# Altium schematic sheet 7 Display_V0.SchDoc
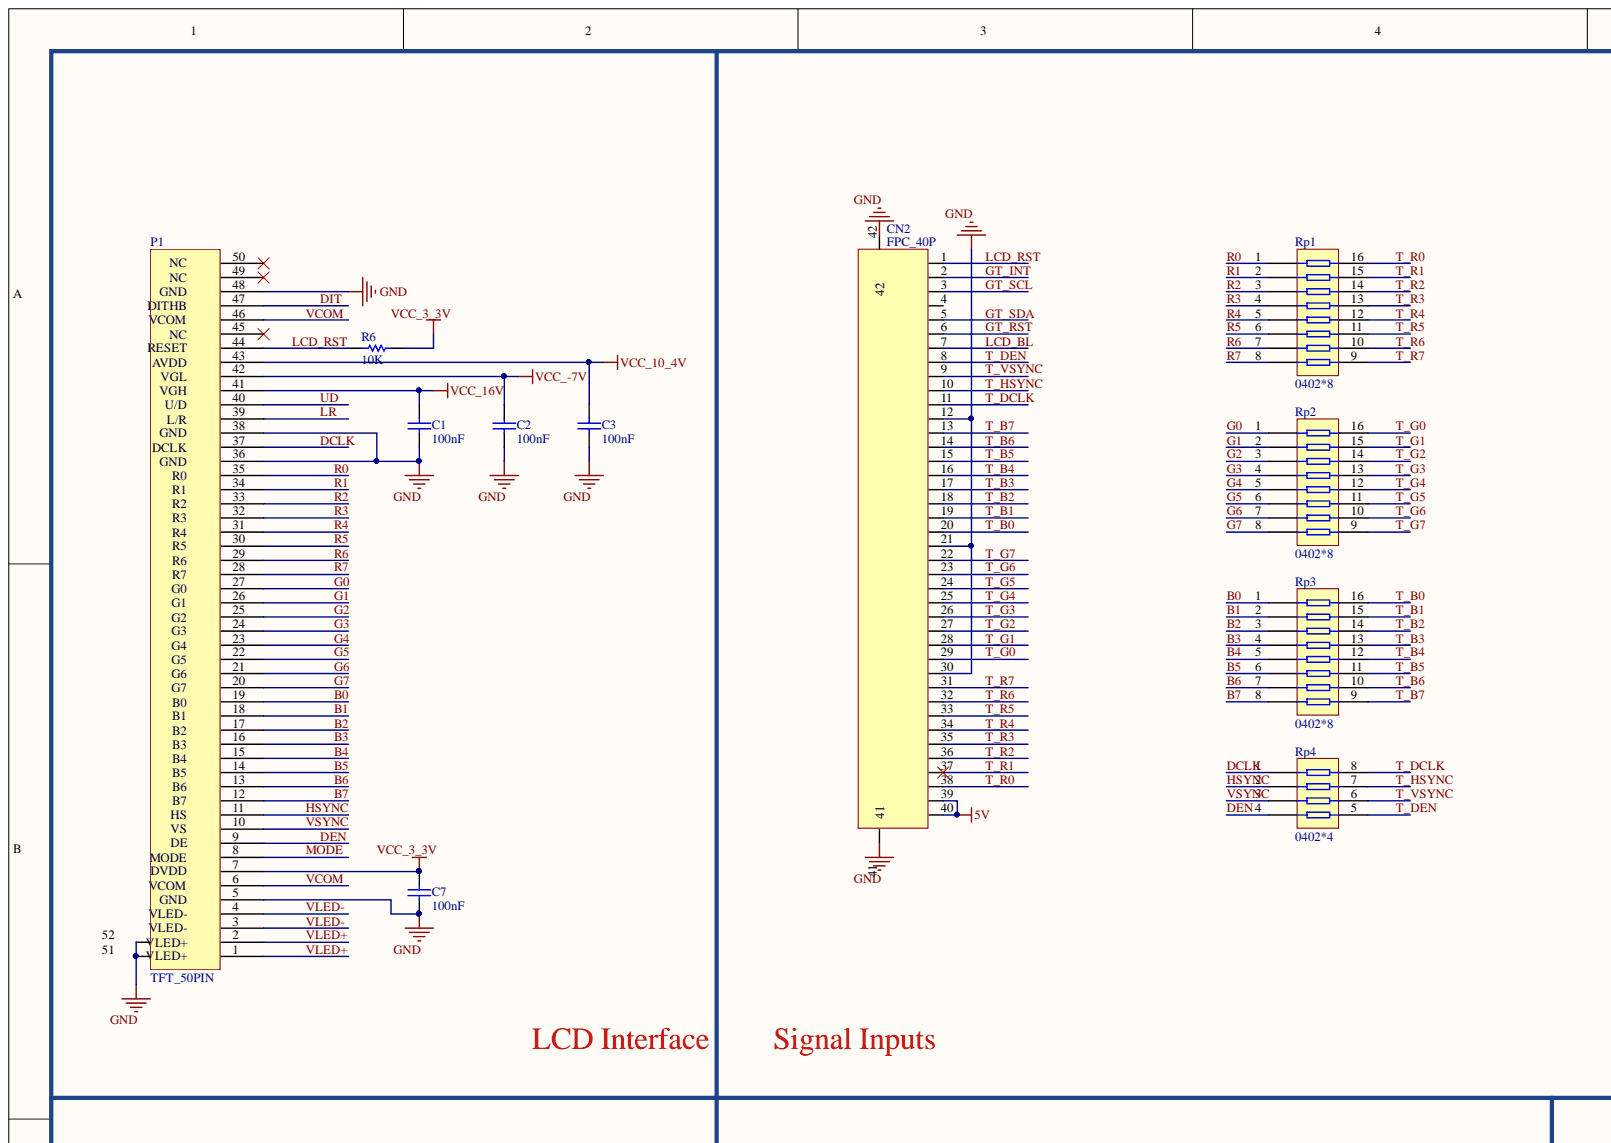
[diagram p0: 7 Display_V0.SchDoc, top left]
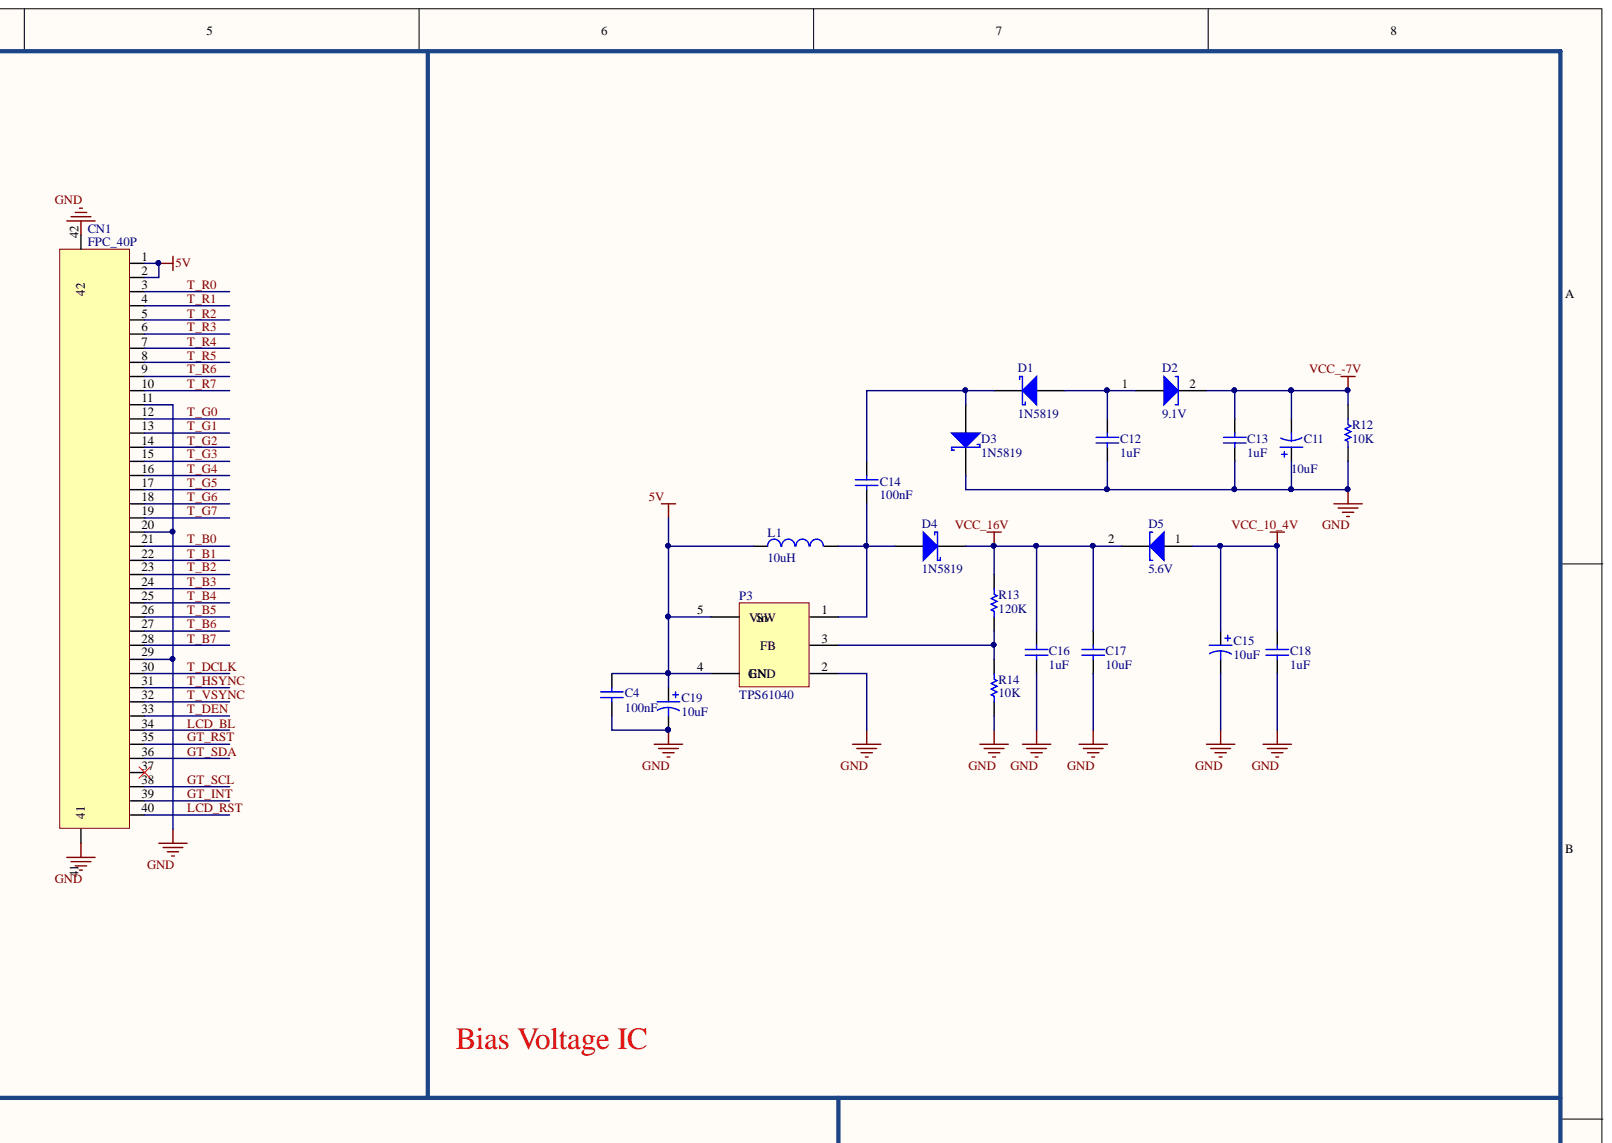
[diagram p1: 7 Display_V0.SchDoc, top right]
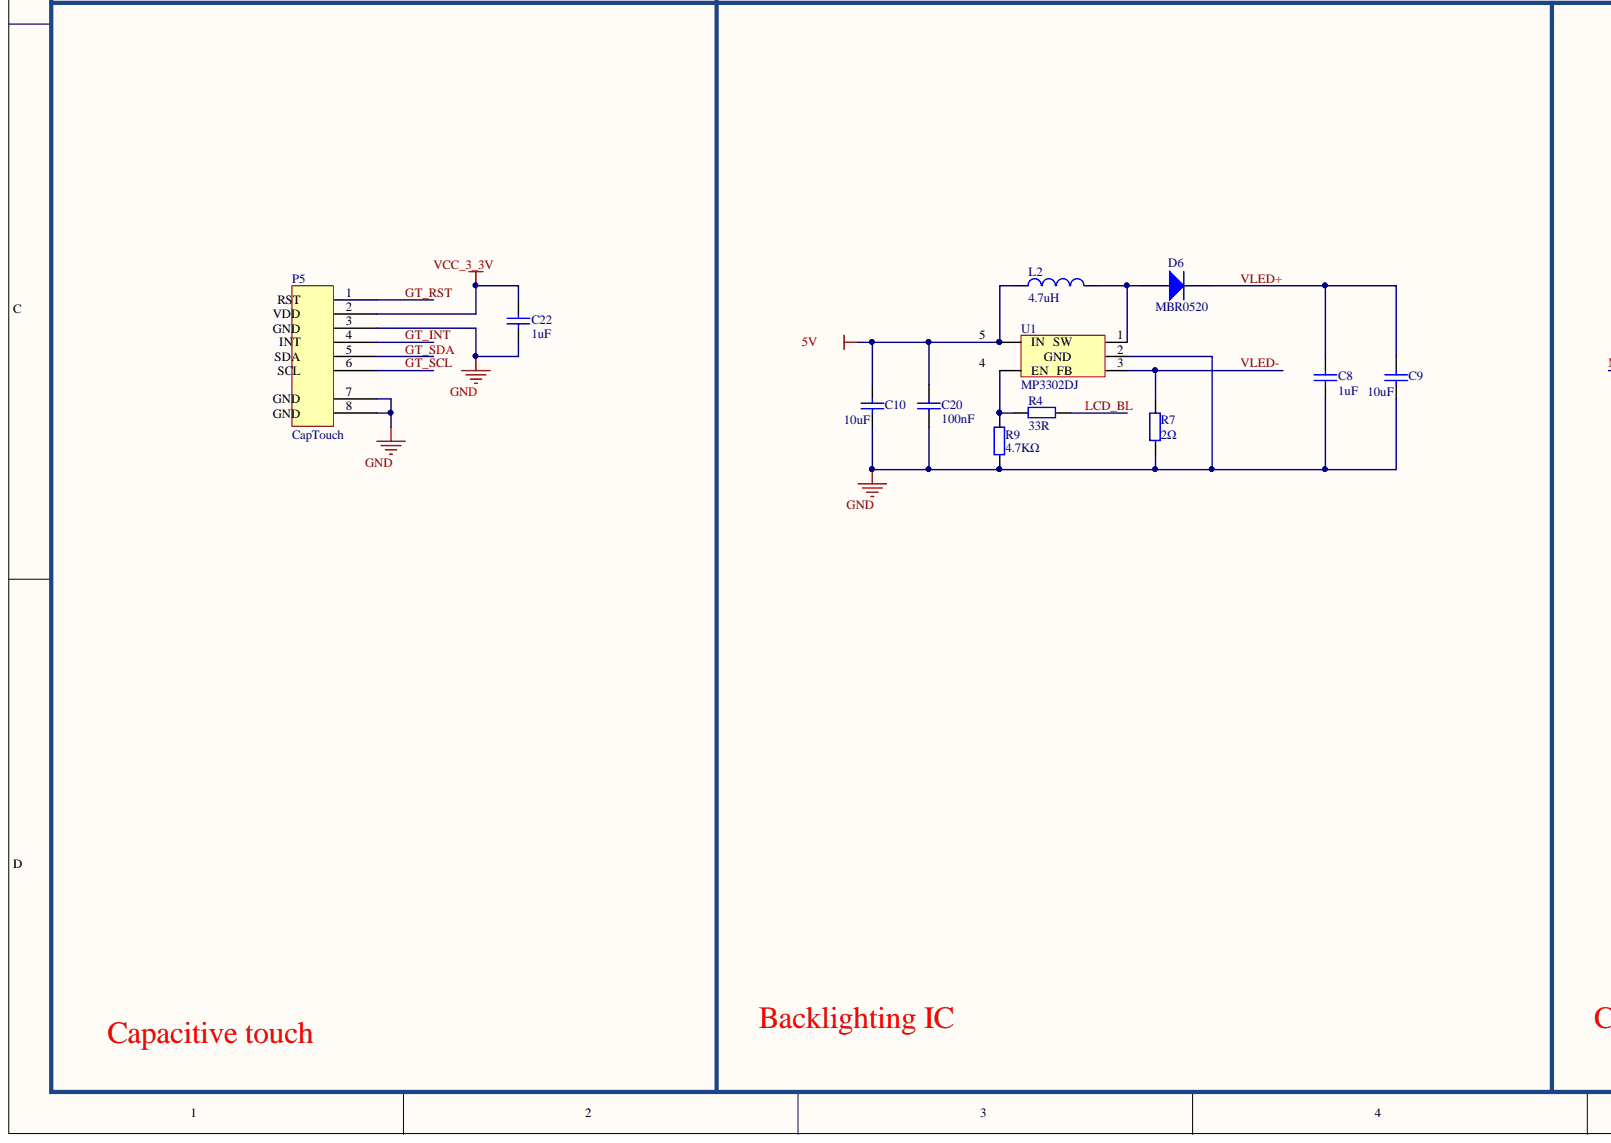
[diagram p2: 7 Display_V0.SchDoc, bottom left]
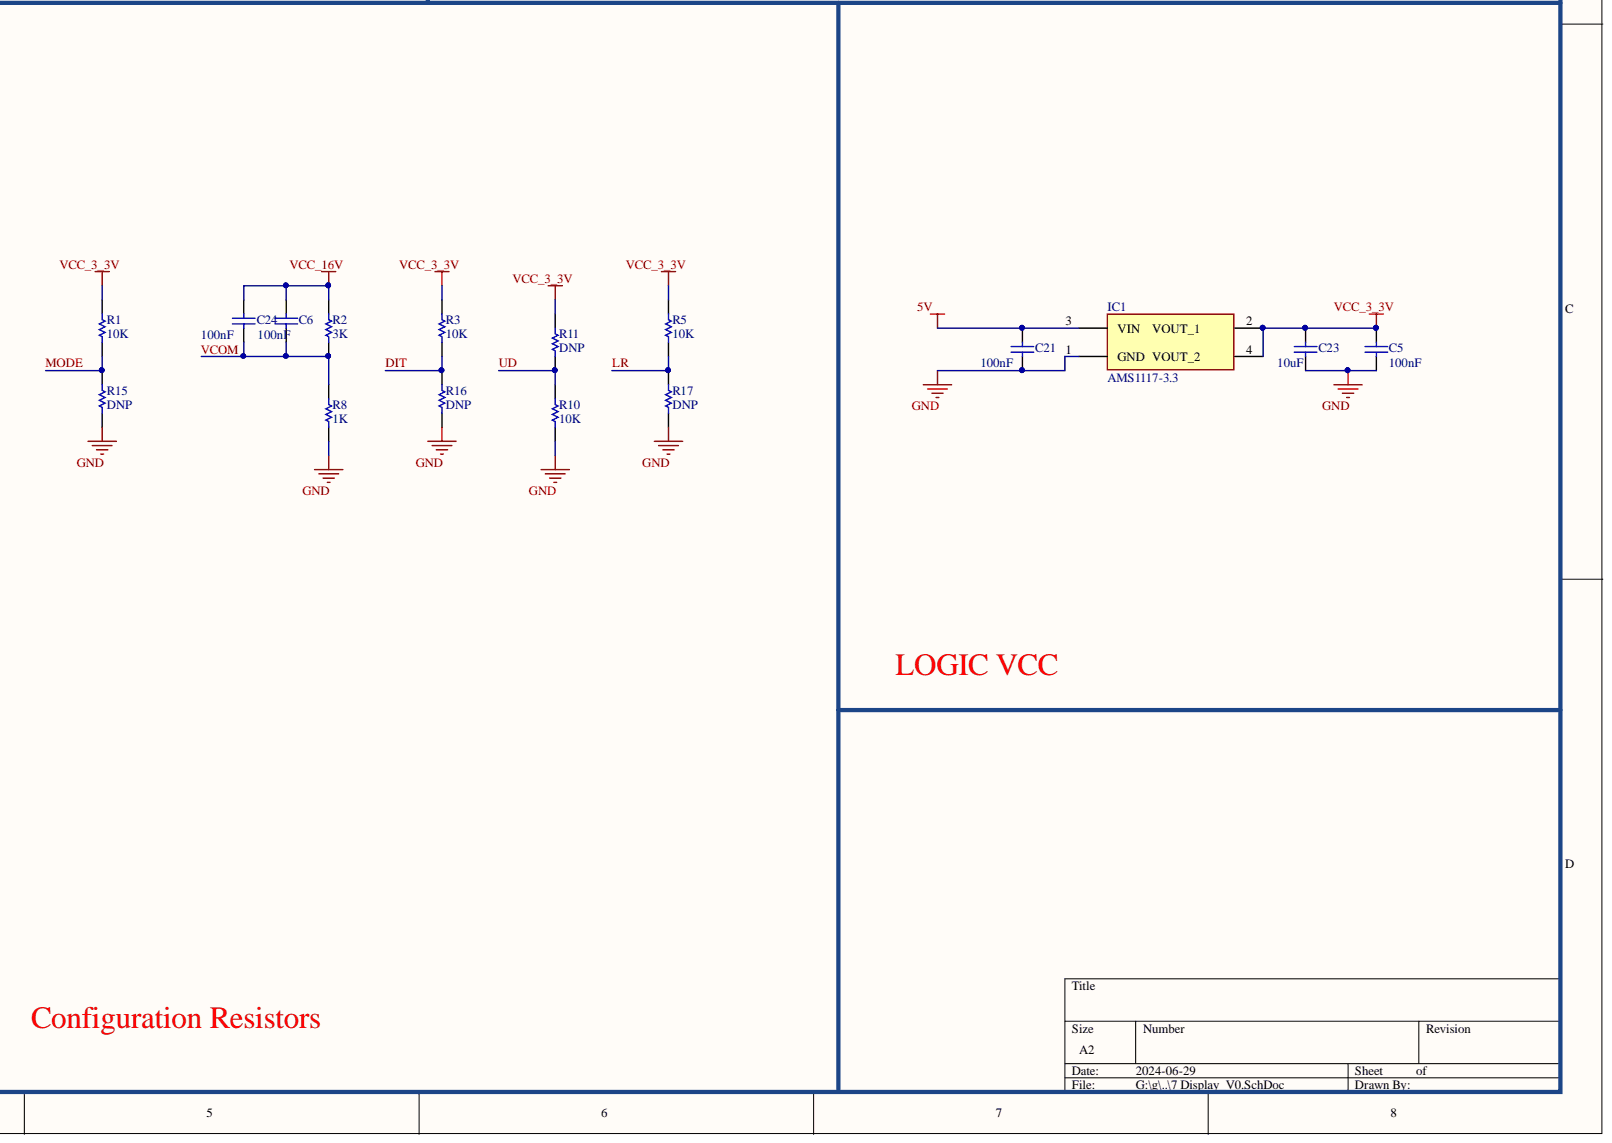
[diagram p3: 7 Display_V0.SchDoc, bottom right]

## Parts
C1  100nF  [C0603]
C2  100nF  [C0603]
C3  100nF  [C0603]
C4  100nF  [C0603]
C5  100nF  [C0603]
C6  100nF  [C0603]
C7  100nF  [C0603]
C8  1uF  [0603_C]
C9  10uF  [1206_C]
C10  10uF  [1206_C]
C11  10uF  [1206_C]
C12  1uF  [C0603]
C13  1uF  [C0603]
C14  100nF  [C0603]
C15  10uF  [1206_C]
C16  1uF  [C0603]
C17  10uF  [1206_C]
C18  1uF  [C0603]
C19  10uF  [1206_C]
C20  100nF  [0603_C]
C21  100nF  [0603_C]
C22  1uF  [C0603]
C23  10uF  [1206_C]
C24  100nF  [C0603]
CN1  FPC_40P  [FPC-SMD_P0.50-40P_FGS-XJ-H2.0]
CN2  FPC_40P  [FPC-SMD_P0.50-40P_FGS-XJ-H2.0]
D1  1N5819  [CAPMP4327X24N]
D2  9.1V  [CAPMP4327X24N]
D3  1N5819  [CAPMP4327X24N]
D4  1N5819  [CAPMP4327X24N]
D5  5.6V  [CAPMP4327X24N]
D6  MBR0520  [SOD-123]
IC1  AMS1117-3.3  [SOT229P700X180-4N]
L1  10uH  [L1008]
L2  4.7uH  [L1008]
P1  TFT_50PIN  [FPC50]
P3  TPS61040  [SOT95P280X110-5N]
P5  CapTouch  [FPC6]
R1  10K  [R0603]
R2  3K  [R0603]
R3  10K  [R0603]
R4  33R  [0603_R]
R5  10K  [R0603]
R6  10K  [R0603]
R7  2Ω  [0603_R]
R8  1K  [R0603]
R9  4.7KΩ  [0603_R]
R10  10K  [R0603]
R11  DNP  [R0603]
R12  10K  [R0603]
R13  120K  [R0603]
R14  10K  [R0603]
R15  DNP  [R0603]
R16  DNP  [R0603]
R17  DNP  [R0603]
Rp1  0402*8  [0402_Rx8]
Rp2  0402*8  [0402_Rx8]
Rp3  0402*8  [0402_Rx8]
Rp4  0402*4  [0402_Rx4]
U1  MP3302DJ  [SOT-23-5]

## Nets
5V: C4.2 C10.2 C19.1 C20.2 C21.2 CN1.1 CN1.2 CN2.39 CN2.40 IC1.3(VIN) L1.1 L2.1 P3.4(GND) P3.5(Vin) U1.5(IN)
GND: C1.1 C2.1 C3.1 C4.1 C5.1 C7.1 C8.2 C9.1 C10.1 C11.1 C12.1 C13.1 C15.2 C16.1 C17.1 C18.1 C19.2 C20.1 C21.1 C22.1 C23.1 CN1.11 CN1.20 CN1.29 CN1.41 CN1.42 CN2.12 CN2.21 CN2.30 CN2.41 CN2.42 D3.2 IC1.1(GND) P1.5 P1.36 P1.38 P1.48 P1.51(VLED+) P1.52(VLED+) P3.2 P5.3 P5.7 P5.8 R7.2 R8.1 R9.1 R10.1 R12.1 R14.1 R15.1 R16.1 R17.1 U1.2
VCC_3_3V: C5.2 C7.2 C22.2 C23.2 IC1.2 IC1.4 P1.7 P5.2 R1.2 R3.2 R5.2 R6.1 R11.2
VCC_10_4V: C3.2 C15.1 C18.2 D5.1 P1.43
VCC_16V: C1.2 C6.2 C16.2 C17.2 C24.2 D4.2 D5.2 P1.41 R2.2 R13.2
VCC_-7V: C2.2 C11.2 C13.2 D2.2 P1.42 R12.2
B0: P1.19 Rp3.1
B1: P1.18 Rp3.2
B2: P1.17 Rp3.3
B3: P1.16 Rp3.4
B4: P1.15 Rp3.5
B5: P1.14 Rp3.6
B6: P1.13 Rp3.7
B7: P1.12 Rp3.8
DCLK: P1.37 Rp4.1
DEN: P1.9 Rp4.4
DIT: P1.47 R3.1 R16.2
G0: P1.27 Rp2.1
G1: P1.26 Rp2.2
G2: P1.25 Rp2.3
G3: P1.24 Rp2.4
G4: P1.23 Rp2.5
G5: P1.22 Rp2.6
G6: P1.21 Rp2.7
G7: P1.20 Rp2.8
GT_INT: CN1.39 CN2.2 P5.4
GT_RST: CN1.35 CN2.6 P5.1
GT_SCL: CN1.38 CN2.3 P5.6
GT_SDA: CN1.36 CN2.5 P5.5
HSYNC: P1.11 Rp4.2
LCD_BL: CN1.34 CN2.7 R4.2
LCD_RST: CN1.40 CN2.1 P1.44 R6.2
LR: P1.39 R5.1 R17.2
MODE: P1.8 R1.1 R15.2
R0: P1.35 Rp1.1
R1: P1.34 Rp1.2
R2: P1.33 Rp1.3
R3: P1.32 Rp1.4
R4: P1.31 Rp1.5
R5: P1.30 Rp1.6
R6: P1.29 Rp1.7
R7: P1.28 Rp1.8
T_B0: CN1.21 CN2.20 Rp3.16
T_B1: CN1.22 CN2.19 Rp3.15
T_B2: CN1.23 CN2.18 Rp3.14
T_B3: CN1.24 CN2.17 Rp3.13
T_B4: CN1.25 CN2.16 Rp3.12
T_B5: CN1.26 CN2.15 Rp3.11
T_B6: CN1.27 CN2.14 Rp3.10
T_B7: CN1.28 CN2.13 Rp3.9
T_DCLK: CN1.30 CN2.11 Rp4.8
T_DEN: CN1.33 CN2.8 Rp4.5
T_G0: CN1.12 CN2.29 Rp2.16
T_G1: CN1.13 CN2.28 Rp2.15
T_G2: CN1.14 CN2.27 Rp2.14
T_G3: CN1.15 CN2.26 Rp2.13
T_G4: CN1.16 CN2.25 Rp2.12
T_G5: CN1.17 CN2.24 Rp2.11
T_G6: CN1.18 CN2.23 Rp2.10
T_G7: CN1.19 CN2.22 Rp2.9
T_HSYNC: CN1.31 CN2.10 Rp4.7
T_R0: CN1.3 CN2.38 Rp1.16
T_R1: CN1.4 CN2.37 Rp1.15
T_R2: CN1.5 CN2.36 Rp1.14
T_R3: CN1.6 CN2.35 Rp1.13
T_R4: CN1.7 CN2.34 Rp1.12
T_R5: CN1.8 CN2.33 Rp1.11
T_R6: CN1.9 CN2.32 Rp1.10
T_R7: CN1.10 CN2.31 Rp1.9
T_VSYNC: CN1.32 CN2.9 Rp4.6
UD: P1.40 R10.2 R11.1
VCOM: C6.1 C24.1 P1.6 P1.46 R2.1 R8.2
VLED+: C8.1 C9.2 D6.2 P1.1 P1.2
VLED-: P1.3 P1.4 R7.1 U1.3
VSYNC: P1.10 Rp4.3
NetC12_2: C12.2 D1.1 D2.1
NetC14_1: C14.1 D4.1 L1.2 P3.1
NetC14_2: C14.2 D1.2 D3.1
NetD6_1: D6.1 L2.2 U1.1
NetP3_3: P3.3 R13.1 R14.2
NetR4_1: R4.1 R9.2 U1.4(EN)

Not connected: CN1 37; CN2 4; P1 45 49 50

## Library data of the parts
C1-C7 C12-C14 C16-C18 C22 C24 = Cap: Capacitor; Component Kind: Standard; LatestRevisionDate: 17-Jul-2002; LatestRevisionNote: Re-released for DXP Platform.; Library Name: Miscellaneous Devices.IntLib; PackageDescription: Radial Cap, Thru-Hole; 2 Leads; 0.3 in Pin Spacing; PackageReference: RAD-0.3; Published: 8-Jun-2000; Signal Integrity: Cap; Simulation: CAP; Publisher: Altium Limited
  C1-C7 C14 C24: Value: 100nF
  C12 C13 C16 C18 C22: Value: 1uF
  C17: Value: 10uF
C8 = 0805 1uF (105) 10% 50V: 贴片电容; Component Kind: Standard; ComponentLink1Description: 供应商链接; ComponentLink1URL: http://www.szlcsc.com/product/details_29074.html; Library Name: Miscellaneous Devices LC.IntLib; Package: 0805; Supplier: LC; SuppliersPartNumber: C28323
C9 C10 C23 = 1206 1uF (105) 10% 50V: 贴片电容; Component Kind: Standard; ComponentLink1Description: 供应商链接; ComponentLink1URL: http://www.szlcsc.com/product/details_2200.html; Library Name: Miscellaneous Devices LC.IntLib; Package: 1206; Supplier: LC; SuppliersPartNumber: C1848
C11 C15 C19 = Cap Pol1: Polarized Capacitor (Radial); Component Kind: Standard; LatestRevisionDate: 17-Jul-2002; LatestRevisionNote: Re-released for DXP Platform.; Library Name: Miscellaneous Devices.IntLib; PackageDescription: Polarized Capacitor (Radial); 2 Leads; PackageReference: RB7.6-15; Published: 8-Jun-2000; Signal Integrity: Cap Pol; Simulation: CAP; Value: 10uF; Publisher: Altium Limited
C20 C21 = 0603 100nF (104) 10% 50V: 贴片电容; Component Kind: Standard; ComponentLink1Description: 供应商链接; ComponentLink1URL: http://www.szlcsc.com/product/details_15331.html; Library Name: Miscellaneous Devices LC.IntLib; Package: 0603; Supplier: LC; SuppliersPartNumber: C14663
D1 D3 D4 = Diode 11DQ03: SMA; Code_JEDEC: DO-204-AL; Component Kind: Standard; ComponentLink1Description: Manufacturer Link; ComponentLink1URL: http://www.irf.com/; ComponentLink2Description: Datasheet; ComponentLink2URL: http://www.irf.com/product-info/datasheets/data/11dq03.pdf; DatasheetVersion: Dec-1997; LatestRevisionDate: 17-Jul-2002; LatestRevisionNote: Re-released for DXP Platform.; Library Name: Miscellaneous Devices.IntLib; PackageDescription: Diode, Axial; Body 5.2 x 2.7 mm (LxDia. max), Lead Dia. 0.86 mm (max); PackageReference: DO-204AL; Published: 8-Jun-2000; Simulation: 11DQ03; Publisher: Altium Limited
D2 = D Tunnel2: 齐纳二极管/ZMM9V1/ BZT52C9V1; Component Kind: Standard; LatestRevisionDate: 17-Jul-2002; LatestRevisionNote: Re-released for DXP Platform.; Library Name: Miscellaneous Devices.IntLib; PackageDescription: Diode, Thru-Hole; 2 Leads; 0.4 in Pin Spacing; PackageReference: DIODE-0.4; Published: 15-Apr-2002; Signal Integrity: D Tunnel; Simulation: DTUNNEL2; Publisher: Altium Limited
D5 = D Tunnel2: 齐纳二极管/ZMM5V6-M/BZT52C5V6S; Component Kind: Standard; LatestRevisionDate: 17-Jul-2002; LatestRevisionNote: Re-released for DXP Platform.; Library Name: Miscellaneous Devices.IntLib; PackageDescription: Diode, Thru-Hole; 2 Leads; 0.4 in Pin Spacing; PackageReference: DIODE-0.4; Published: 15-Apr-2002; Signal Integrity: D Tunnel; Simulation: DTUNNEL2; Publisher: Altium Limited
D6 = SOD-123 B5819W  SL: 肖特基二极管; Component Kind: Standard; ComponentLink1Description: 供应商链接; ComponentLink1URL: http://www.szlcsc.com/product/details_9093.html; Library Name: Miscellaneous Devices LC.IntLib; Package: SOD-123; Supplier: LC; SuppliersPartNumber: C8598
IC1 = AMS1117-3.3: Integrated Circuit; Manufacturer_Name: Advanced Monolithic Systems; Component Kind: Standard; Datasheet Link: http://www.advanced-monolithic.com/pdf/ds1117.pdf; Height: 1.8mm; Library Name: MY_LIB.SchLib; Manufacturer_Part_Number: AMS1117-3.3
L1 = Inductor: Code_IEC: 1005; Code_JEITA: 0402; Component Kind: Standard; Description: Inductor; LatestRevisionDate: 29-May-2009; LatestRevisionNote: IPC-7351 Footprint Added.; Library Name: Miscellaneous Devices.IntLib; PackageDescription: Chip Inductor; PackageReference: 0402-A; Published: 8-Jun-2000; Signal Integrity: Inductor; Simulation: INDUCTOR; Value: 10uH; Publisher: Altium Limited
L2 = Inductor: Code_IEC: 1005; Value: 10uH; Code_JEITA: 0402; Component Kind: Standard; Description: Inductor; LatestRevisionDate: 29-May-2009; LatestRevisionNote: IPC-7351 Footprint Added.; Library Name: Miscellaneous Devices.IntLib; PackageDescription: Chip Inductor; PackageReference: 0402-A; Published: 8-Jun-2000; Signal Integrity: Inductor; Simulation: INDUCTOR; Publisher: Altium Limited
R1-R3 R5 R6 R8 R10 R12-R14 = Res1: Resistor; Component Kind: Standard; LatestRevisionDate: 17-Jul-2002; LatestRevisionNote: Re-released for DXP Platform.; Library Name: Miscellaneous Devices.IntLib; PackageDescription: Axial Device, Thru-Hole; 2 Leads; 0.3 in Pin Spacing; PackageReference: AXIAL-0.3; Published: 8-Jun-2000; Signal Integrity: Res; Simulation: RESISTOR; Publisher: Altium Limited
  R1 R3 R5 R6 R10 R12 R14: Value: 10K
  R2: Value: 3K
  R8: Value: 1K
  R13: Value: 120K
R4 = 0603 10KΩ (1002) 1%: 贴片电阻; Component Kind: Standard; ComponentLink1Description: 供应商链接; ComponentLink1URL: http://www.szlcsc.com/product/details_26547.html; Library Name: Miscellaneous Devices LC.IntLib; Package: 0603; Supplier: LC; SuppliersPartNumber: C25804
R7 = 0603 2Ω (2R00) 1%: 贴片电阻; Component Kind: Standard; ComponentLink1Description: 供应商链接; ComponentLink1URL: http://www.szlcsc.com/product/details_23704.html; Library Name: Miscellaneous Devices LC.IntLib; Package: 0603; Supplier: LC; SuppliersPartNumber: C22977
R9 = 0603 4.7KΩ (4701) 1%: 贴片电阻; Component Kind: Standard; ComponentLink1Description: 供应商链接; ComponentLink1URL: http://www.szlcsc.com/product/details_23889.html; Library Name: Miscellaneous Devices LC.IntLib; Package: 0603; Supplier: LC; SuppliersPartNumber: C23162
R11 R15-R17 = Res1: Component Kind: Standard; LatestRevisionDate: 17-Jul-2002; LatestRevisionNote: Re-released for DXP Platform.; Library Name: Miscellaneous Devices.IntLib; PackageDescription: Axial Device, Thru-Hole; 2 Leads; 0.3 in Pin Spacing; PackageReference: AXIAL-0.3; Published: 8-Jun-2000; Signal Integrity: Res; Simulation: RESISTOR; Value: DNP; Publisher: Altium Limited
Rp1-Rp3 = 0402*8: 贴片排阻; Component Kind: Standard; ComponentLink1Description: 供应商链接; ComponentLink1URL: http://www.szlcsc.com/product/details_26469.html; Library Name: MY_LIB.SchLib; Package: 0402*4; Supplier: LC; SuppliersPartNumber: C25726
Rp4 = 0402*4: 贴片排阻; Component Kind: Standard; ComponentLink1Description: 供应商链接; ComponentLink1URL: http://www.szlcsc.com/product/details_26469.html; Library Name: MY_LIB.SchLib; Package: 0402*4; Supplier: LC; SuppliersPartNumber: C25726
U1 = MP3302: LCD背光IC; Component Kind: Standard; Library Name: ATK-MD0700R_V1.4.IntLib
CN1 CN2 = FPC_40P: Component Kind: Standard; Library Name: MY_LIB.SchLib
P1 = TFT_50PIN: Component Kind: Standard; Library Name: ScreenSchilib.SchLib
P3 = TPS61040: Component Kind: Standard; Library Name: ScreenSchilib.SchLib
P5 = CapTouch: Component Kind: Standard; Library Name: ScreenSchilib.SchLib
